# Altium schematic sheet hellen1-knock.SchDoc(hellen1-knock)
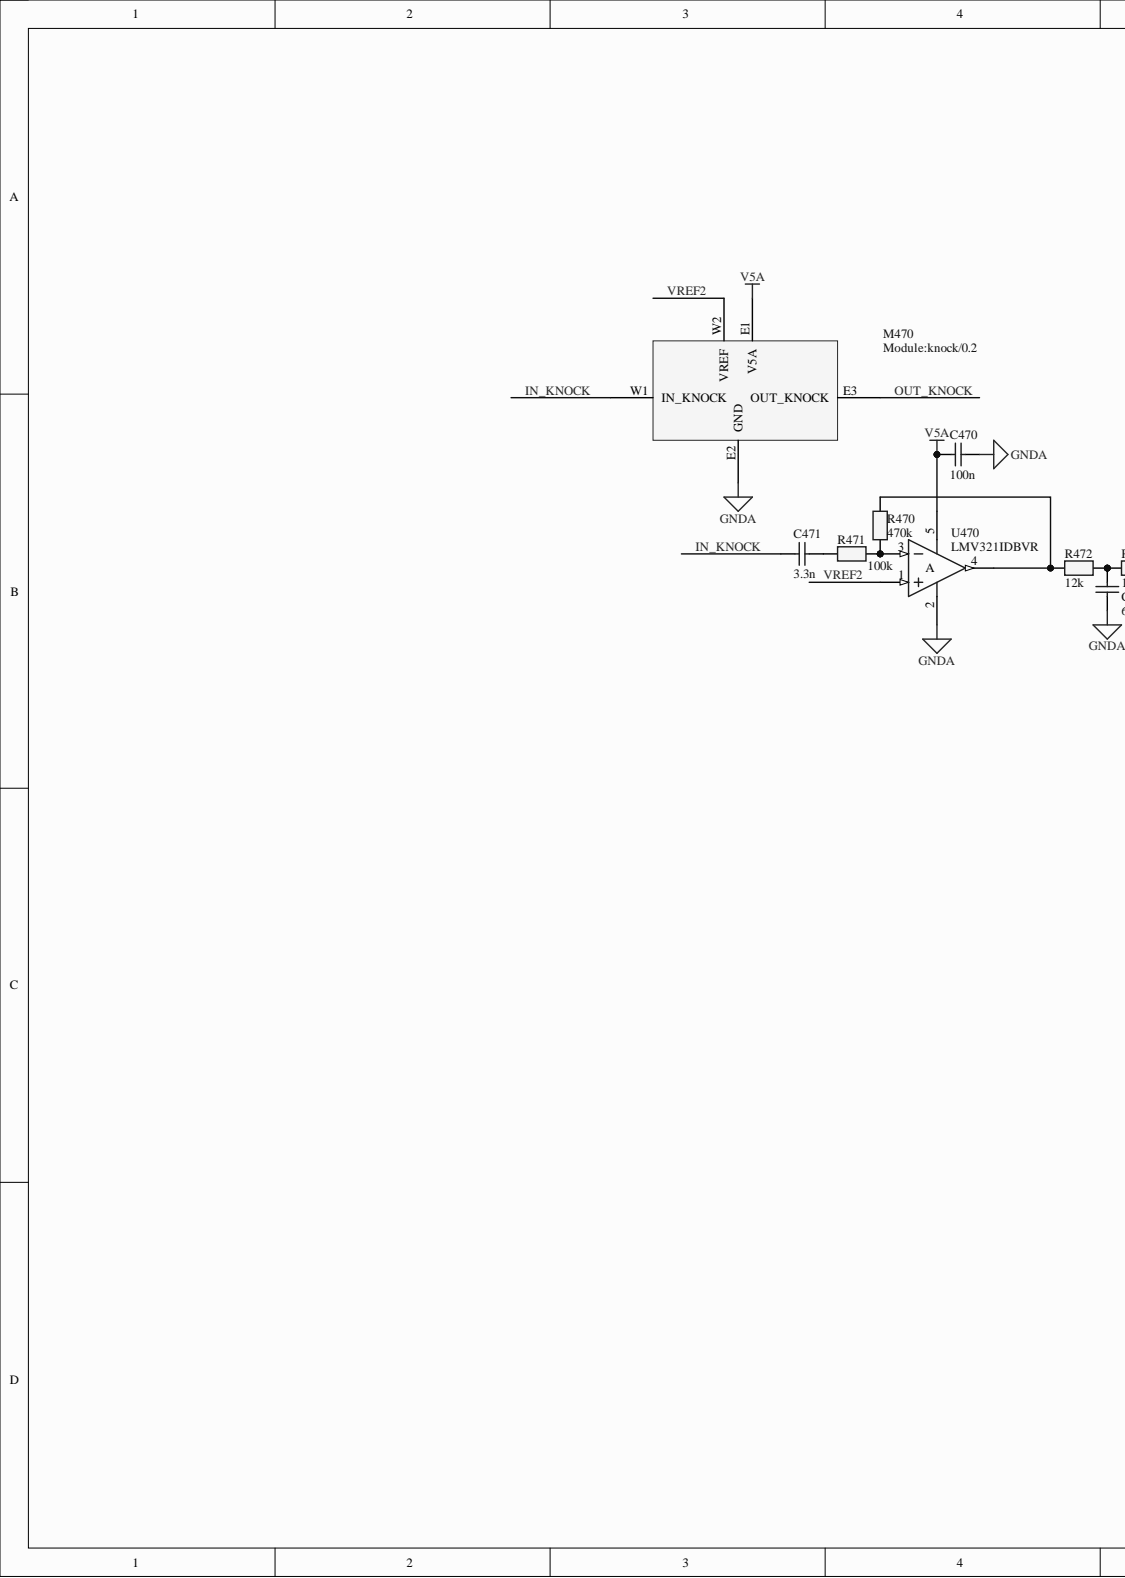
[diagram p0: hellen1-knock.SchDoc, left]
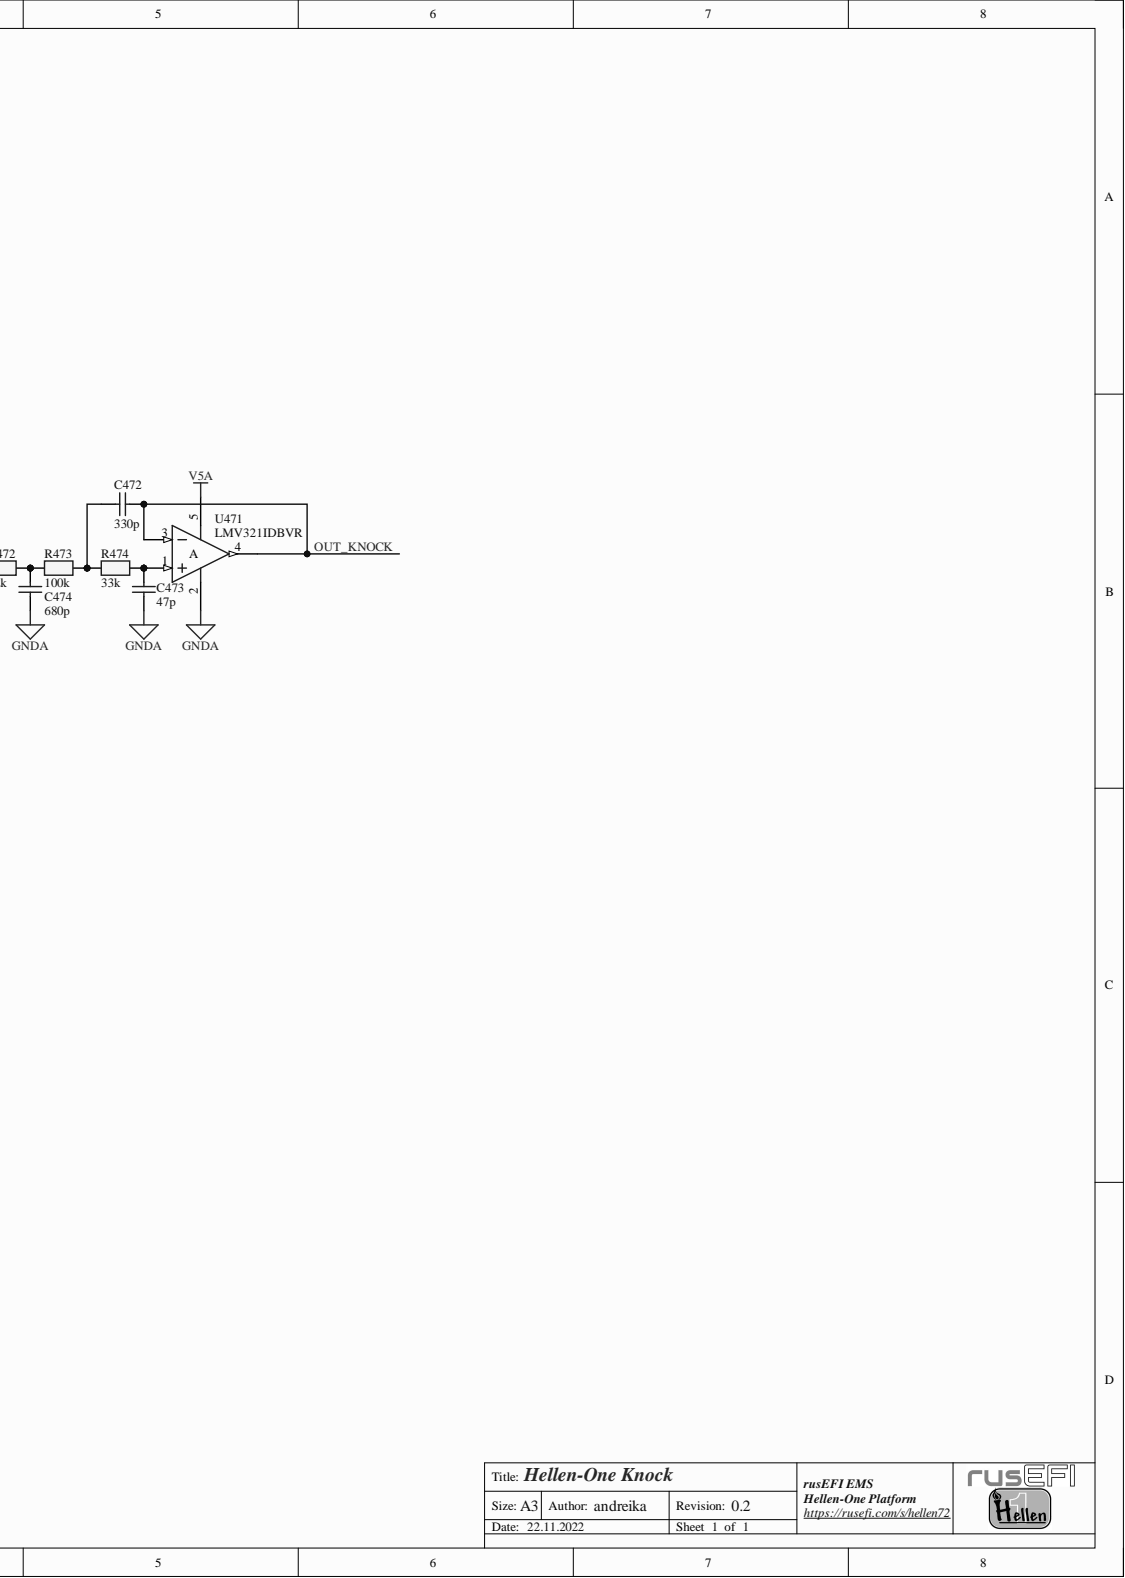
[diagram p1: hellen1-knock.SchDoc, right]

## Parts
C470  100n  [C0402]
C471  3.3n  [C0402]
C472  330p  [C0402]
C473  47p  [C0402]
C474  680p  [C0402]
M470  Module:knock/0.2  [MOD_Hellen_Knock]
R470  470k  [R0402]
R471  100k  [R0402]
R472  12k  [R0402]
R473  100k  [R0402]
R474  33k  [R0402]
U470  LMV321IDBVR  [SOT-23-5]
U471  LMV321IDBVR  [SOT-23-5]

## Nets
GNDA: C470.2 C473.1 C474.1 M470.E2(GND) U470.2 U471.2
V5A: C470.1 M470.E1(V5A) U470.5 U471.5
IN_KNOCK: C471.1 M470.W1(IN_KNOCK)
OUT_KNOCK: C472.2 M470.E3(OUT_KNOCK) U471.3 U471.4
VREF2: M470.W2(VREF) U470.1
NetC471_2: C471.2 R471.2
NetC472_1: C472.1 R473.1 R474.2
NetC473_2: C473.2 R474.1 U471.1
NetC474_2: C474.2 R472.1 R473.2
NetR470_1: R470.1 R472.2 U470.4
NetR470_2: R470.2 R471.1 U470.3

## Library data of the parts
C470-C474 = Cap: Capacitor; Fitted: True; Type: SMD; Component Kind: Standard; PackageReference: C0603; Signal Integrity: Capacitor; Supplier 1: Mouser; Supplier 2: DigiKey; Supplier 3: LCSC; Library Name: hellen1-knock.SCHLIB
  C470: Supplier Part Number 3: C14663
  C471: Supplier Part Number 3: C1613
  C472: Supplier Part Number 3: C1664
  C473: Supplier Part Number 3: C1671
  C474: Supplier Part Number 3: C1630
M470 = Mod-Hellen-Knock: Hellen-One Knock Pre-amplifier Module; Fitted: False; Component Kind: Standard; Library Name: hellen1-knock.SCHLIB; Supplier 1: Mouser; Supplier 2: Digi-Key; Supplier 3: LCSC; Type: Module; Publisher: andreika
R470-R474 = Res: Resistor; Fitted: True; Component Kind: Standard; PackageReference: R0603; PCB3D: 0805; Signal Integrity: Resistor; Supplier 1: Mouser; Supplier 2: DigiKey; Supplier 3: LCSC; Type: SMD; Library Name: hellen1-knock.SCHLIB
  R470: Supplier Part Number 3: C23178
  R471 R473: Supplier Part Number 3: C25803
  R472: Supplier Part Number 3: C22790
  R474: Supplier Part Number 3: C4216
U470 U471 = LMV321: Low-Voltage Rail-to-Rail Output Operational Amplifiers; Fitted: True; Code_IPC: SOIC127P600-8; Code_JEDEC: MS-012-AA; Component Kind: Standard; ComponentLink1Description: Manufacturer Link; ComponentLink1URL: http://www.analog.com/en/audiovideo-products/audio-amplifiers/ad8542/products/product.html; ComponentLink2Description: Datasheet; ComponentLink2URL: http://www.analog.com/static/imported-files/data_sheets/AD8541_8542_8544.pdf; DatasheetVersion: REV. A 1997; LatestRevisionDate: 13-Apr-2006; LatestRevisionNote: IPC-7351 Footprint Added.; PackageDescription: 8-Pin Small Outline Integrated Circuit 1.27 mm Pitch; PackageReference: SOT23-5; PackageVersion: revA; Published: 8-Jun-2000; Supplier 3: LCSC; Supplier Part Number 3: C7972; Type: SMD; Library Name: hellen1-knock.SCHLIB; Publisher: Altium Limited
